# Altium schematic sheet FM.SchDoc(FM)
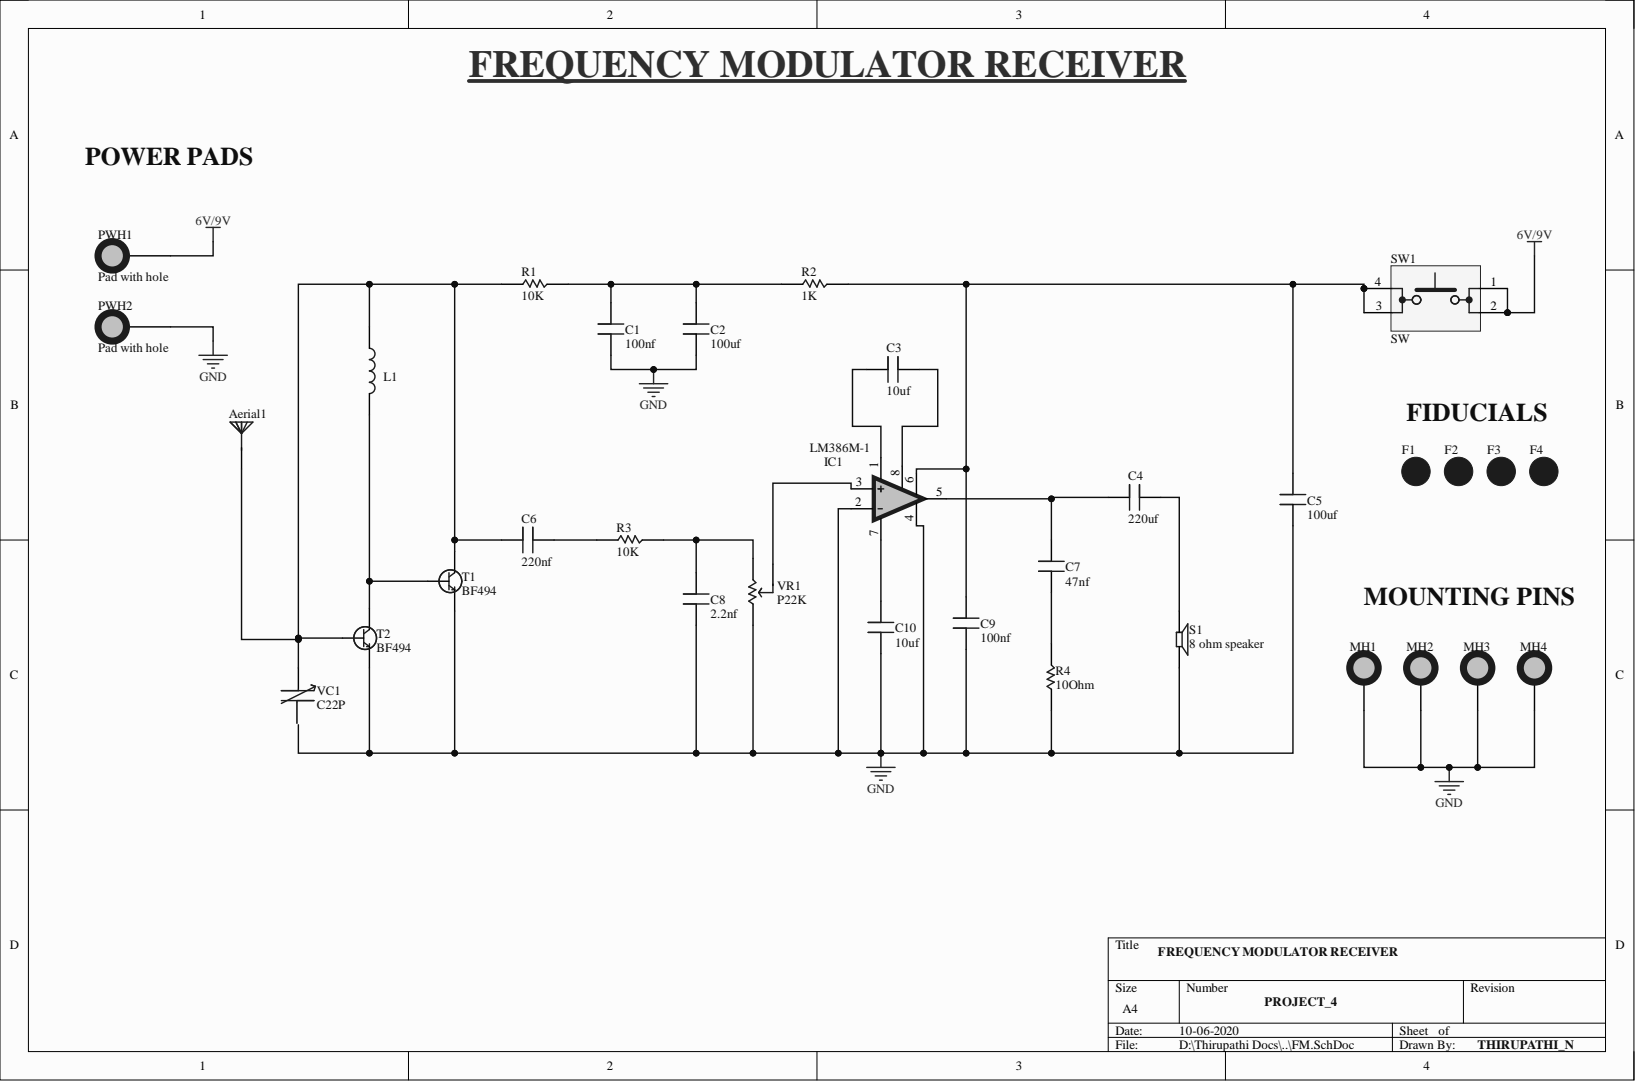
[diagram p0: FM.SchDoc]

## Parts
Aerial1  Aerial  [Aerial]
C1  100nf  [C0805]
C2  100uf  [C0805]
C3  10uf  [C0805]
C4  220uf  [C220uf]
C5  100uf  [C0805]
C6  220nf  [C0805]
C7  47nf  [C0805]
C8  2.2nf  [C0805]
C9  100nf  [C0805]
C10  10uf  [C0805]
F1  fid  [fid]  (value not drawn)
F2  fid  [fid]  (value not drawn)
F3  fid  [fid]  (value not drawn)
F4  fid  [fid]  (value not drawn)
IC1  LM386M-1  [LM386]
L1  L  [L]
MH1  mounting holes  [Mounting Holes]  (value not drawn)
MH2  mounting holes  [Mounting Holes]  (value not drawn)
MH3  mounting holes  [Mounting Holes]  (value not drawn)
MH4  mounting holes  [Mounting Holes]  (value not drawn)
PWH1  Pad with hole  [PWH]
PWH2  Pad with hole  [PWH]
R1  10K  [R0805]
R2  1K  [R0805]
R3  10K  [R0805]
R4  10Ohm  [R0805]
S1  8 ohm speaker  [8 ohm speaker]
SW1  SW  [SW]
T1  BF494  [BF494]
T2  BF494  [BF494]
VC1  C22P  [C22P]
VR1  P22K  [P22K]

## Nets
6V/9V: PWH1.1 SW1.1 SW1.2
GND: C1.1 C2.1 C5.1 C8.1 C9.1 C10.1 IC1.2 IC1.4 MH1.1 MH2.1 MH3.1 MH4.1 PWH2.1 R4.1 S1.1 T1.2 T2.2 VR1.1
NetAerial1_1: Aerial1.1 C6.1 L1.1 R1.1 T1.3 T2.1 VC1.2
NetC1_2: C1.2 C2.2 R1.2 R2.1
NetC3_1: C3.1 IC1.1
NetC3_2: C3.2 IC1.8
NetC4_1: C4.1 C7.2 IC1.5
NetC4_2: C4.2 S1.2
NetC5_2: C5.2 C9.2 IC1.6 R2.2 SW1.3 SW1.4
NetC6_2: C6.2 R3.1
NetC7_1: C7.1 R4.2
NetC8_2: C8.2 R3.2 VR1.2
NetC10_2: C10.2 IC1.7
NetIC1_3: IC1.3 VR1.3
NetL1_2: L1.2 T1.1 T2.3

Not connected: VC1 1

## Library data of the parts
Aerial1 = Aerial: High Frequency Ceramic Solutions 4-Pin SMD T/R; Manufacturer: Johanson; Manufacturer Part Number: 2450AT43B100E; Frequency: 2.4GHz; Gain: -0.5dB; Impedance: 50R; Max Frequency: 2.5GHz; Max Power Dissipation: 2W; Number of Bands: 1; Return Loss: -9.5dB; Case/Package: SMD/SMT; Category: RF Antennas; Component Kind: Standard; ComponentLink1Description: https://datasheet.ciiva.com/24068/2450at43b100-242468-24068034.pdf?src-supplier=Mouser; ComponentLink1URL: https://datasheet.ciiva.com/24068/2450at43b100-242468-24068034.pdf?src-supplier=Mouser; ComponentLink2Description: https://datasheet.ciiva.com/2792/jti-antenna-2450at43b100-09042008-2792593.pdf?src-supplier=Digi-Key; ComponentLink2URL: https://datasheet.ciiva.com/2792/jti-antenna-2450at43b100-09042008-2792593.pdf?src-supplier=Digi-Key; ComponentLink3Description: https://datasheet.ciiva.com/7633/1860765-7633973.pdf?src-supplier=Element14; ComponentLink3URL: https://datasheet.ciiva.com/7633/1860765-7633973.pdf?src-supplier=Element14; Contact Plating: Tin; Height: 0.079inch; Length: 7mm; Library Name: FM.SchLib; Mount: Surface Mount; Number of Pins: 4; Package Quantity: 1; Packaging: Tape and Reel; Power Rating: 2W; Pricing 1: 1=0.71000, 10=0.62400, 25=0.58600, 100=0.47820, 250=0.44416, 500=0.37800 (USD); Radiation Hardening: No; REACH SVHC: Unknown; RoHS: Yes; Stock 1: 20930; Supplier 1: Digi-Key; Supplier Part Number 1: 712-1010-1-ND; Termination: Solder; Width: 2mm
C1 C9 = 100nf: Cap Cer 0.1UF 100V X7R 0805; Manufacturer: KEMET; Manufacturer Part Number: C0805X104J1RAC7800; Component Kind: Standard; ComponentLink1Description: http://datasheet.octopart.com/C0805X104J1RAC7800-KEMET-datasheet-84169754.pdf; ComponentLink1URL: http://datasheet.octopart.com/C0805X104J1RAC7800-KEMET-datasheet-84169754.pdf; Library Name: FM.SchLib; Pricing 1: 1=0.54000, 10=0.38200, 50=0.28840, 100=0.25130, 500=0.18612, 1000=0.15820 (USD); Stock 1: 2400; Supplier 1: Digi-Key; Supplier Part Number 1: 399-C0805X104J1RAC7800CT-ND
C2 C5 = 100uf: Chip Capacitor; 0805; X5R; 6.3V; 100uF; ±20%; Manufacturer: Venkel; Manufacturer Part Number: C0805X5R6R3-107MNE; Component Kind: Standard; Library Name: FM.SchLib; RoHS: No; Supplier 1: Digi-Key; Supplier Part Number 1: 2679-C0805X5R6R3-107MNETR-ND
C3 C10 = 10uf: Multilayer Ceramic Capacitors MLCC - SMD/SMT 6.3volts 10uF X5R 10%; Manufacturer: KEMET; Manufacturer Part Number: C0805L106K9PACTU; Voltage Rating: 6.3V; Capacitance: 10uF; Case Code (Imperial): 0805; Case Code (Metric): 2012; Case/Package: 0805; Category: Ceramic Capacitors; Component Kind: Standard; ComponentLink1Description: https://datasheet.ciiva.com/13379/kem-c1006-x5r-smd-504728-13379146.pdf?src-supplier=Mouser; ComponentLink1URL: https://datasheet.ciiva.com/13379/kem-c1006-x5r-smd-504728-13379146.pdf?src-supplier=Mouser; ComponentLink2Description: https://datasheet.ciiva.com/148/mlcclowprofile-148553.pdf?src-supplier=Digi-Key; ComponentLink2URL: https://datasheet.ciiva.com/148/mlcclowprofile-148553.pdf?src-supplier=Digi-Key; ComponentLink3Description: https://datasheet.ciiva.com/148/mlcclowprofile-148551.pdf?src-supplier=Digi-Key; ComponentLink3URL: https://datasheet.ciiva.com/148/mlcclowprofile-148551.pdf?src-supplier=Digi-Key; ComponentLink4Description: https://datasheet.ciiva.com/148/mlcclowprofile-148552.pdf?src-supplier=Digi-Key; ComponentLink4URL: https://datasheet.ciiva.com/148/mlcclowprofile-148552.pdf?src-supplier=Digi-Key; Dielectric: X5R; Length: 0.079inch; Library Name: FM.SchLib; Max Operating Temperature: 85°C; Min Operating Temperature: -55°C; Mount: Surface Mount; Package Quantity: 4000; Packaging: Tape and Reel; Pricing 1: 1=0.99000, 10=0.75100, 50=0.62380, 100=0.53710, 500=0.41580, 1000=0.35805 (USD); RoHS: Yes; Stock 1: 3700; Supplier 1: Digi-Key; Supplier Part Number 1: 399-5930-1-ND; Termination: SMD/SMT; Thickness: 0.037inch; Tolerance: 10%; Voltage Rating (DC): 6.3V; Width: 0.049inch
C4 = 220uf: CAP CER 220UF 6.3V X5R 1206; Manufacturer: Murata; Manufacturer Part Number: GRM31CR60J227ME11L; Tolerance: 20%; Capacitance: 220uF; Case Code (Imperial): 1206; Case Code (Metric): 3216; Case/Package: 1206; Category: Ceramic Capacitors; Component Kind: Standard; ComponentLink1Description: http://www.farnell.com/datasheets/2867879.pdf?src-supplier=Farnell; ComponentLink1URL: http://www.farnell.com/datasheets/2867879.pdf?src-supplier=Farnell; ComponentLink2Description: http://www.farnell.com/datasheets/2867879.pdf?src-supplier=Element14; ComponentLink2URL: http://www.farnell.com/datasheets/2867879.pdf?src-supplier=Element14; ComponentLink3Description: http://www.farnell.com/datasheets/1957826.pdf?src-supplier=Newark; ComponentLink3URL: http://www.farnell.com/datasheets/1957826.pdf?src-supplier=Newark; ComponentLink4Description: http://search.murata.co.jp/Ceramy/image/img/A01X/partnumbering_e_01.pdf?src-supplier=Digi-Key; ComponentLink4URL: http://search.murata.co.jp/Ceramy/image/img/A01X/partnumbering_e_01.pdf?src-supplier=Digi-Key; ComponentLink5Description: http://search.murata.co.jp/Ceramy/image/img/A01X/G101/ENG/GRM31CR60J227ME11-01.pdf?src-supplier=Digi-Key; ComponentLink5URL: http://search.murata.co.jp/Ceramy/image/img/A01X/G101/ENG/GRM31CR60J227ME11-01.pdf?src-supplier=Digi-Key; Dielectric: X5R; Length: 0.126inch; Library Name: FM.SchLib; Max Operating Temperature: 85°C; Min Operating Temperature: -55°C; Mount: Surface Mount; Packaging: Cut Tape; Pricing 1: 1=1.60000, 10=1.26100, 50=1.09320, 100=0.94610, 500=0.71480, 1000=0.63071 (USD); REACH SVHC: No SVHC; RoHS: Yes; Stock 1: 333882; Supplier 1: Digi-Key; Supplier Part Number 1: 490-13970-1-ND; Thickness: 0.075inch; Voltage Rating: 6.3V; Voltage Rating (DC): 6.3V; Width: 0.063inch
C6 = 220nf: Cap Ceramic 0.22uF 100V X7R 5% SMD 0805 125°C T/R; Manufacturer: KEMET; Manufacturer Part Number: C0805C224J1REC7800; Category: Ceramic Capacitors; Component Kind: Standard; Library Name: FM.SchLib; Pricing 1: 1=0.30000, 10=0.20400, 50=0.13600, 100=0.11560, 500=0.08158, 1000=0.07138 (USD); RoHS: No; Stock 1: 2456; Supplier 1: Digi-Key; Supplier Part Number 1: 399-C0805C224J1REC7800CT-ND
C7 = 47nf: Manufacturer: KEMET; Manufacturer Part Number: C0805C473J3GEC7800; Component Kind: Standard; Library Name: FM.SchLib; Pricing 1: 1=0.44000, 10=0.30600, 50=0.21440, 100=0.18380, 500=0.13786, 1000=0.11641 (USD); RoHS: No; Stock 1: 645; Supplier 1: Digi-Key; Supplier Part Number 1: 399-C0805C473J3GEC7800CT-ND
C8 = 2.2nf: Cap Ceramic 0.0022uF 100V X7R 10% SMD 0805 125°C Paper T/R; Manufacturer: KEMET; Manufacturer Part Number: C0805X222K1RAC7800; Capacitance: 2.2nF; Case/Package: 0805; Category: Ceramic Capacitors; Component Kind: Standard; ComponentLink1Description: http://capacitoredge.kemet.com/capedge2/DataSheet?pn=C0805X222K1RAC7800&src-supplier=Avnet; ComponentLink1URL: http://capacitoredge.kemet.com/capedge2/DataSheet?pn=C0805X222K1RAC7800&src-supplier=Avnet; ComponentLink2Description: http://capacitoredge.kemet.com/capedge2/DataSheet?pn=C0805X222K1RACTU&src-supplier=Avnet+Europe; ComponentLink2URL: http://capacitoredge.kemet.com/capedge2/DataSheet?pn=C0805X222K1RACTU&src-supplier=Avnet+Europe; Depth: 1.25mm; Dielectric: X7R; Dielectric Material: Ceramic; Height: 0.78mm; Length: 2.1mm; Library Name: FM.SchLib; Max Operating Temperature: 125°C; Min Operating Temperature: -55°C; Mount: Surface Mount; Pricing 1: 1=0.38000, 10=0.26700, 50=0.18660, 100=0.16000, 500=0.12002, 1000=0.10135 (USD); Radiation Hardening: No; RoHS: Yes; Stock 1: 4000; Supplier 1: Digi-Key; Supplier Part Number 1: 399-C0805X222K1RAC7800CT-ND; Tolerance: 10%; Voltage Rating (DC): 100V
IC1 = LM386M-1: Audio Amp Speaker 1-CH Mono 0.325W Class-AB 8-Pin SOIC N Rail; Manufacturer: TI National Semiconductor; Bandwidth: 300kHz; Input Bias Current: 250nA; Input Offset Voltage (Vos): 2.5mV; Load Impedance: 8R; Max Output Power: 325mW; Max Power Dissipation: 730mW; Max Supply Voltage: 12V; Min Supply Voltage: 4V; Nominal Supply Current: 4mA; Number of Amplifiers: 1; Power Supply Rejection Ratio (PSRR): 50dB; Quiescent Current: 8mA; Supply Type: Single; Voltage Gain: 46dB; Case/Package: D; Category: Amplifiers - Audio; Component Kind: Standard; ComponentLink1Description: https://datasheet.ciiva.com/27746/82-0264e-27746609.pdf?src-supplier=Rapid+Electronics; ComponentLink1URL: https://datasheet.ciiva.com/27746/82-0264e-27746609.pdf?src-supplier=Rapid+Electronics; ComponentLink2Description: https://datasheet.ciiva.com/14667/0900766b800333d0-14667266.pdf?src-supplier=RSComponents; ComponentLink2URL: https://datasheet.ciiva.com/14667/0900766b800333d0-14667266.pdf?src-supplier=RSComponents; ComponentLink3Description: https://datasheet.ciiva.com/19456/193623-19456045.pdf?src-supplier=Jameco; ComponentLink3URL: https://datasheet.ciiva.com/19456/193623-19456045.pdf?src-supplier=Jameco; ComponentLink4Description: https://datasheet.ciiva.com/26842/lm386m-1-26842536.pdf?src-supplier=IIIC; ComponentLink4URL: https://datasheet.ciiva.com/26842/lm386m-1-26842536.pdf?src-supplier=IIIC; ComponentLink5Description: https://datasheet.ciiva.com/26330/142336-da-01-en-ic-smd-lm-386-m-1-so8-ti-26330975.pdf?src-supplier=Conrad; ComponentLink5URL: https://datasheet.ciiva.com/26330/142336-da-01-en-ic-smd-lm-386-m-1-so8-ti-26330975.pdf?src-supplier=Conrad; ComponentLink6Description: https://datasheet.ciiva.com/1480/1640767-1480992.pdf?src-supplier=Element14; ComponentLink6URL: https://datasheet.ciiva.com/1480/1640767-1480992.pdf?src-supplier=Element14; ComponentLink7Description: https://datasheet.ciiva.com/1482/1640767-1482305.pdf?src-supplier=Element14; ComponentLink7URL: https://datasheet.ciiva.com/1482/1640767-1482305.pdf?src-supplier=Element14; ComponentLink8Description: https://datasheet.ciiva.com/2967/lm386-2967890.pdf?src-supplier=Avnet; ComponentLink8URL: https://datasheet.ciiva.com/2967/lm386-2967890.pdf?src-supplier=Avnet; Height: 1.45mm; Lead Free: Contains Lead; Length: 4.9mm; Library Name: FM.SchLib; Manufacturer Part Number: LM386M-1; Max Operating Temperature: 70°C; Min Operating Temperature: 0°C; Number of Channels: 1; Number of Pins: 8; Output Power: 325mW; Package Quantity: 95; Packaging: Rail/Tube; Power Dissipation: 730mW; Pricing 1: 1=1.39000, 10=1.24500, 25=1.18160, 100=0.90730, 250=0.80180, 500=0.75960 (USD); REACH SVHC: No SVHC; RoHS: Yes; Stock 1: 607; Supplier 1: Digi-Key; Supplier Part Number 1: LM386M-1-ND; Termination: SMD/SMT; Unity Gain Bandwidth Product: 300kHz; Weight: 0.006lb; Width: 3.9mm
L1 = L: Fixed Inductors 0402 AIR CORE 1.5nH .3nH; Manufacturer: Murata; Manufacturer Part Number: LQG15HN1N5S00D; DC Resistance (DCR): 100mR; Max DC Current: 300mA; Self Resonant Frequency: 6GHz; Case/Package: 0402; Category: Fixed Inductors; Component Kind: Standard; ComponentLink1Description: http://www.mouser.com/ds/2/281/o05e-522697.pdf?src-supplier=Mouser; ComponentLink1URL: http://www.mouser.com/ds/2/281/o05e-522697.pdf?src-supplier=Mouser; Inductance: 1.5nH; Length: 1mm; Library Name: FM.SchLib; Package Quantity: 10000; Packaging: Tape and Reel; RoHS: Yes; Supplier 1: Mouser; Supplier Part Number 1: 81-LQG15HN1N5S00D; Termination: SMD/SMT; Width: 0.5mm
R1 R3 = 10K: BOURNS         CR0805-JW-103ELF            Surface Mount Chip Resistor, Thick Film, CR Series, 10 kohm, 125 mW,  5%, 150 V; Max Power Dissipation: 125mW; Resistance: 10kR; Temperature Coefficient: 200ppm/°C; Voltage Rating: 150V; Voltage Rating (DC): 100V; Case Code (Imperial): 0805; Case Code (Metric): 2012; Case/Package: 0805; Category: Chip SMD Resistors; Component Kind: Standard; ComponentLink1Description: https://datasheet.ciiva.com/11821/0900766b80fcdb72-11821135.pdf?src-supplier=RSComponents; ComponentLink1URL: https://datasheet.ciiva.com/11821/0900766b80fcdb72-11821135.pdf?src-supplier=RSComponents; ComponentLink2Description: https://datasheet.ciiva.com/9122/chpreztr-9122374.pdf?src-supplier=Avnet; ComponentLink2URL: https://datasheet.ciiva.com/9122/chpreztr-9122374.pdf?src-supplier=Avnet; ComponentLink3Description: https://datasheet.ciiva.com/22693/1902866-22693254.pdf?src-supplier=Element14; ComponentLink3URL: https://datasheet.ciiva.com/22693/1902866-22693254.pdf?src-supplier=Element14; ComponentLink4Description: https://datasheet.ciiva.com/7986/691949-7986187.pdf?src-supplier=Element14; ComponentLink4URL: https://datasheet.ciiva.com/7986/691949-7986187.pdf?src-supplier=Element14; ComponentLink5Description: https://datasheet.ciiva.com/30065/159chpreztr-30065976.pdf?src-supplier=Onlinecomponents.com; ComponentLink5URL: https://datasheet.ciiva.com/30065/159chpreztr-30065976.pdf?src-supplier=Onlinecomponents.com; ComponentLink6Description: https://datasheet.ciiva.com/16783/70154685-16783482.pdf?src-supplier=Allied+Electronics; ComponentLink6URL: https://datasheet.ciiva.com/16783/70154685-16783482.pdf?src-supplier=Allied+Electronics; ComponentLink7Description: https://datasheet.ciiva.com/5548/chpreztr-5548491.pdf?src-supplier=Mouser; ComponentLink7URL: https://datasheet.ciiva.com/5548/chpreztr-5548491.pdf?src-supplier=Mouser; ComponentLink8Description: https://datasheet.ciiva.com/6559/chpreztr-6559900.pdf?src-supplier=Digi-Key; ComponentLink8URL: https://datasheet.ciiva.com/6559/chpreztr-6559900.pdf?src-supplier=Digi-Key; ComponentLink9Description: https://datasheet.ciiva.com/6559/chpreztr-6559901.pdf?src-supplier=Digi-Key; ComponentLink9URL: https://datasheet.ciiva.com/6559/chpreztr-6559901.pdf?src-supplier=Digi-Key; ComponentLink10Description: https://datasheet.ciiva.com/7985/1856384-7985869.pdf?src-supplier=Element14; ComponentLink10URL: https://datasheet.ciiva.com/7985/1856384-7985869.pdf?src-supplier=Element14; ComponentLink11Description: https://datasheet.ciiva.com/48692/001055517-da-01-en-smd-wid-cr0805-jw-103elf-10k-ohm-1-8w-48692118.pdf?src-supplier=Conrad; ComponentLink11URL: https://datasheet.ciiva.com/48692/001055517-da-01-en-smd-wid-cr0805-jw-103elf-10k-ohm-1-8w-48692118.pdf?src-supplier=Conrad; Contact Plating: Tin; Depth: 1.25mm; Features: Moisture Resistant; Height: 0.5mm; Length: 2mm; Library Name: FM.SchLib; Manufacturer: Bourns; Manufacturer Part Number: CR0805-JW-103ELF; Max Operating Temperature: 155°C; Military Standard: Not; Min Operating Temperature: -55°C; Mount: Surface Mount; Number of Pins: 2; Number of Terminations: 2; Package Quantity: 1; Packaging: Tape and Reel; Power Rating: 125mW; Pricing 1: 1=0.10000, 10=0.01900, 100=0.00770, 1000=0.00345, 2500=0.00299 (USD); Radiation Hardening: No; REACH SVHC: No SVHC; Resistor Type: Thick Film; RoHS: Yes; Stock 1: 237038; Supplier 1: Digi-Key; Supplier Part Number 1: CR0805-JW-103ELFCT-ND; Termination: SMD/SMT; Thickness: 508um; Tolerance: 5%; Width: 1.25mm
R2 = 1K: RES SMD 1K OHM 5% 1/8W 0805; Manufacturer: Bourns; Manufacturer Part Number: CR0805-JW-102ELF; Max Power Dissipation: 125mW; Resistance: 1kR; Temperature Coefficient: 200ppm/°C; Voltage Rating: 150V; Case Code (Imperial): 0805; Case Code (Metric): 2012; Case/Package: 0805; Category: Chip SMD Resistors; Component Kind: Standard; ComponentLink1Description: https://datasheet.ciiva.com/11821/0900766b80fcdb72-11821134.pdf?src-supplier=RSComponents; ComponentLink1URL: https://datasheet.ciiva.com/11821/0900766b80fcdb72-11821134.pdf?src-supplier=RSComponents; ComponentLink2Description: https://datasheet.ciiva.com/15225/getdatasheetpartid-78155-15225381.pdf?src-supplier=Verical; ComponentLink2URL: https://datasheet.ciiva.com/15225/getdatasheetpartid-78155-15225381.pdf?src-supplier=Verical; ComponentLink3Description: https://datasheet.ciiva.com/22693/1902866-22693253.pdf?src-supplier=Element14; ComponentLink3URL: https://datasheet.ciiva.com/22693/1902866-22693253.pdf?src-supplier=Element14; ComponentLink4Description: https://datasheet.ciiva.com/7986/1856384-7986184.pdf?src-supplier=Element14; ComponentLink4URL: https://datasheet.ciiva.com/7986/1856384-7986184.pdf?src-supplier=Element14; ComponentLink5Description: https://datasheet.ciiva.com/16934/chpreztr-16934442.pdf?src-supplier=Avnet+Europe; ComponentLink5URL: https://datasheet.ciiva.com/16934/chpreztr-16934442.pdf?src-supplier=Avnet+Europe; ComponentLink6Description: https://datasheet.ciiva.com/7985/1856384-7985867.pdf?src-supplier=Element14; ComponentLink6URL: https://datasheet.ciiva.com/7985/1856384-7985867.pdf?src-supplier=Element14; ComponentLink7Description: https://datasheet.ciiva.com/30242/159chpreztr-30242765.pdf?src-supplier=Onlinecomponents.com; ComponentLink7URL: https://datasheet.ciiva.com/30242/159chpreztr-30242765.pdf?src-supplier=Onlinecomponents.com; ComponentLink8Description: https://datasheet.ciiva.com/6547/chpreztr-6547359.pdf?src-supplier=Digi-Key; ComponentLink8URL: https://datasheet.ciiva.com/6547/chpreztr-6547359.pdf?src-supplier=Digi-Key; Contact Plating: Tin; Depth: 1.25mm; Features: Moisture Resistant; Height: 0.5mm; Lead Free: Lead Free; Length: 2mm; Library Name: FM.SchLib; Max Operating Temperature: 155°C; Military Standard: Not; Min Operating Temperature: -55°C; Mount: Surface Mount; Number of Pins: 2; Number of Terminations: 2; Package Quantity: 1; Packaging: Tape and Reel; Power Rating: 125mW; Pricing 1: 1=0.10000, 10=0.01900, 100=0.00770, 1000=0.00345, 2500=0.00299 (USD); Radiation Hardening: No; REACH SVHC: No SVHC; Resistor Type: Thick Film; RoHS: Yes; Stock 1: 114420; Supplier 1: Digi-Key; Supplier Part Number 1: CR0805-JW-102ELFCT-ND; Termination: SMD/SMT; Thickness: 508um; Tolerance: 5%; Voltage Rating (DC): 150V; Width: 1.25mm
R4 = 10Ohm: RES, THICK FILM, 10R, 5%, 0.125W, 0805; Product Range:CR Series; Resistance:10ohm; Power Rating:125mW; Resistance Tolerance:± 5%; Voltage Rating:150V; Resistor Case Style:0805 [2012 Metric]; Packaging:Cut Tape; Resistor Element Material:Thick Film; Temperature Coefficient:± 200ppm/°C; MSL:-; SVHC:No SVHC (15-Jun-2015); Manufacturer: Bourns; Manufacturer Part Number: CR0805-JW-100ELF; Max Power Dissipation: 125mW; Voltage Rating: 150V; Case Code (Imperial): 0805; Case Code (Metric): 2012; Case/Package: 0805; Category: Chip SMD Resistors; Component Kind: Standard; ComponentLink1Description: https://datasheet.ciiva.com/11808/0900766b80fcdb72-11808714.pdf?src-supplier=RSComponents; ComponentLink1URL: https://datasheet.ciiva.com/11808/0900766b80fcdb72-11808714.pdf?src-supplier=RSComponents; ComponentLink2Description: https://datasheet.ciiva.com/16934/chpreztr-16934424.pdf?src-supplier=Avnet+Europe; ComponentLink2URL: https://datasheet.ciiva.com/16934/chpreztr-16934424.pdf?src-supplier=Avnet+Europe; Contact Plating: Tin; Depth: 1.25mm; Features: Moisture Resistant; Height: 0.5mm; Length: 2mm; Library Name: FM.SchLib; Max Operating Temperature: 155°C; Military Standard: Not; Min Operating Temperature: -55°C; Mount: Surface Mount; Number of Terminations: 2; Package Quantity: 5000; Packaging: Tape and Reel; Power Rating: 125mW; Pricing 1: 1=0.10000, 10=0.01900, 100=0.00770, 1000=0.00345, 2500=0.00299 (USD); Radiation Hardening: No; REACH SVHC: No SVHC; Resistance: 10R; Resistor Type: Thick Film; RoHS: Yes; Stock 1: 29437; Supplier 1: Digi-Key; Supplier Part Number 1: CR0805-JW-100ELFCT-ND; Temperature Coefficient: 200ppm/°C; Termination: SMD/SMT; Thickness: 508um; Tolerance: 5%; Voltage Rating (DC): 150V; Width: 1.25mm
S1 = 8 ohm speaker: SPEAKER 8OHM 200MW TOP PORT 87DB; Manufacturer: CUI; Impedance: 8R; Max Power Dissipation: 500mW; Shape: Round; Category: Uncategorized; Component Kind: Standard; ComponentLink1Description: http://www.mouser.com/ds/2/670/cvs-1708-515782.pdf?src-supplier=Mouser; ComponentLink1URL: http://www.mouser.com/ds/2/670/cvs-1708-515782.pdf?src-supplier=Mouser; ComponentLink2Description: https://www.cui.com/product/resource/digikeypdf/cvs-1708.pdf?src-supplier=Digi-Key; ComponentLink2URL: https://www.cui.com/product/resource/digikeypdf/cvs-1708.pdf?src-supplier=Digi-Key; Height: 8mm; Lead Free: Lead Free; Library Name: FM.SchLib; Manufacturer Part Number: CVS-1708; Power Rating: 200mW; Pricing 1: 1=3.00000, 10=2.48900, 25=2.29760, 50=2.15880, 100=2.02510, 500=1.73500, 1000=1.71600 (USD); Resistance: 8R; RoHS: Yes; Stock 1: 5605; Supplier 1: Digi-Key; Supplier Part Number 1: 102-2499-ND; Termination: PC Pins
SW1 = SW: Switch Push Button OFF (ON) SPST Round Button 0.05A 24VDC Momentary Contact PC Pins Thru-Hole; Manufacturer: TE Connectivity; Manufacturer Part Number: 3-1825910-1; Actuator Length: 13.4mm; Actuator Material: Nylon; Actuator Type: Standard; Contact Current Rating: 50mA; Contact Resistance: 100mR; Electrical Life: 100000Cycles; Illumination Type: Non-Illuminated,Illuminated; Max Voltage Rating (DC): 24V; Operating Force: 160gf; Switch Function: Off-Mom; Switch Travel: 0.25mm; Category: Pushbutton Switches; Component Kind: Standard; ComponentLink1Description: https://datasheet.ciiva.com/30188/3-1825910-1rqpn3-1825910-1-30188833.pdf?src-supplier=Onlinecomponents.com; ComponentLink1URL: https://datasheet.ciiva.com/30188/3-1825910-1rqpn3-1825910-1-30188833.pdf?src-supplier=Onlinecomponents.com; ComponentLink2Description: https://datasheet.ciiva.com/15184/getdatasheetpartid-154942-15184426.pdf?src-supplier=Verical; ComponentLink2URL: https://datasheet.ciiva.com/15184/getdatasheetpartid-154942-15184426.pdf?src-supplier=Verical; ComponentLink3Description: https://datasheet.ciiva.com/25789/921681-25789223.pdf?src-supplier=Farnell; ComponentLink3URL: https://datasheet.ciiva.com/25789/921681-25789223.pdf?src-supplier=Farnell; ComponentLink4Description: https://datasheet.ciiva.com/45260/eng-cd-1825910-c9-45260023.pdf?src-supplier=Digi-Key; ComponentLink4URL: https://datasheet.ciiva.com/45260/eng-cd-1825910-c9-45260023.pdf?src-supplier=Digi-Key; ComponentLink5Description: https://datasheet.ciiva.com/1532/1061634-1532424.pdf?src-supplier=Element14; ComponentLink5URL: https://datasheet.ciiva.com/1532/1061634-1532424.pdf?src-supplier=Element14; Contact Material: Copper Alloy; Contact Plating: Silver; Depth: 7.8mm; Length: 5.99mm; Library Name: FM.SchLib; Max Current Rating: 50mA; Max Operating Temperature: 85°C; Mechanical Life: 100000Cycles; Min Operating Temperature: -35°C; Mount: Through Hole; Orientation: Straight; Package Quantity: 750; Packaging: Loose Piece; Pricing 1: 1=0.18000, 10=0.17600, 25=0.16680, 100=0.15180, 250=0.14268, 500=0.13054, 1000=0.10930, 2500=0.10626, 5000=0.10019 (USD); REACH SVHC: Unknown; RoHS: Yes; Stock 1: 15414; Supplier 1: Digi-Key; Supplier Part Number 1: 450-1643-ND; Termination: PC Pins; Throw Configuration: SPST; Type: Switch; Voltage Rating (DC): 24V
T1 T2 = BF494: STMICROELECTRONICS - 2N2222A - TRANSISTOR, NPN, 40V, 0.6A, TO18; Collector Base Voltage (VCBO): 75V; Collector Emitter Saturation Voltage: 1V; Current Rating: 600mA; Element Configuration: Single; Emitter Base Voltage (VEBO): 6V; Frequency: 300MHz; hFE Min: 40; Max Power Dissipation: 500mW; Number of Elements: 1; Type: Switch; Case/Package: TO-18; Category: BJTs; Collector Emitter Breakdown Voltage: 40V; Collector Emitter Voltage (VCEO): 40V; Component Kind: Standard; ComponentLink1Description: https://datasheet.ciiva.com/25917/cd00003223-25917094.pdf?src-supplier=Avnet+Europe; ComponentLink1URL: https://datasheet.ciiva.com/25917/cd00003223-25917094.pdf?src-supplier=Avnet+Europe; ComponentLink2Description: https://datasheet.ciiva.com/1194/1449532-1194083.pdf?src-supplier=Element14; ComponentLink2URL: https://datasheet.ciiva.com/1194/1449532-1194083.pdf?src-supplier=Element14; ComponentLink3Description: https://datasheet.ciiva.com/977/cd00003223-977271.pdf?src-supplier=Digi-Key; ComponentLink3URL: https://datasheet.ciiva.com/977/cd00003223-977271.pdf?src-supplier=Digi-Key; ComponentLink4Description: https://datasheet.ciiva.com/16427/cd00003223-16427384.pdf?src-supplier=Future+Electronics; ComponentLink4URL: https://datasheet.ciiva.com/16427/cd00003223-16427384.pdf?src-supplier=Future+Electronics; ComponentLink5Description: https://datasheet.ciiva.com/15192/getdatasheetpartid-292165-15192875.pdf?src-supplier=Verical; ComponentLink5URL: https://datasheet.ciiva.com/15192/getdatasheetpartid-292165-15192875.pdf?src-supplier=Verical; ComponentLink6Description: https://datasheet.ciiva.com/14166/2n2222a-eng-tds-14166093.pdf?src-supplier=Distrelec; ComponentLink6URL: https://datasheet.ciiva.com/14166/2n2222a-eng-tds-14166093.pdf?src-supplier=Distrelec; ComponentLink7Description: https://datasheet.ciiva.com/29323/163147-da-01-en-transistor-2-n-2222-a-to18-stm-29323030.pdf?src-supplier=Conrad; ComponentLink7URL: https://datasheet.ciiva.com/29323/163147-da-01-en-transistor-2-n-2222-a-to18-stm-29323030.pdf?src-supplier=Conrad; Gain Bandwidth Product: 300MHz; Height: 5.3mm; Lead Free: Contains Lead; Length: 5.8mm; Library Name: FM.SchLib; Manufacturer: STMicroelectronics; Manufacturer Part Number: 2N2222A; Max Collector Current: 600mA; Max Frequency: 300MHz; Max Operating Temperature: 175°C; Min Operating Temperature: -65°C; Mount: Through Hole; Number of Pins: 3; Package Quantity: 1000; Packaging: Bulk; Polarity: NPN; Power Dissipation: 500mW; Pricing 1: 1=1.63000 (USD); Radiation Hardening: No; REACH SVHC: No SVHC; RoHS: Yes; Supplier 1: Newark; Supplier Part Number 1: 89K1151; Transition Frequency: 300MHz; Voltage Rating (DC): 75V; Width: 5.8mm
VC1 = C22P: Trimmer / Variable Capacitors 8.0 - 40pF 100volts; Manufacturer: Knowles Voltronics; Manufacturer Part Number: JR400; Capacitance: 0.00000000004F; Q Factor: 1500; Test Frequency: 1MHz; Voltage Rating: 100V; Category: Trimmer / Variable Capacitors; Component Kind: Standard; ComponentLink1Description: http://www.knowlescapacitors.com/getmedia/76b68d57-f62f-4666-a976-d23a42593c78/9341_Voltronics-2014_Final-Proof.aspx?src-supplier=Digi-Key; ComponentLink1URL: http://www.knowlescapacitors.com/getmedia/76b68d57-f62f-4666-a976-d23a42593c78/9341_Voltronics-2014_Final-Proof.aspx?src-supplier=Digi-Key; ComponentLink2Description: http://www.dovercmp.com/voltronics/en/headernavigation/product-selector/productdetails?Product_ID=674&src-supplier=Mouser; ComponentLink2URL: http://www.dovercmp.com/voltronics/en/headernavigation/product-selector/productdetails?Product_ID=674&src-supplier=Mouser; Height: 0.045inch; Length: 0.138inch; Library Name: FM.SchLib; Max Operating Temperature: 85°C; Min Operating Temperature: -40°C; Package Quantity: 1000; Packaging: Tape and Reel; Pricing 1: 1=2.68000, 10=2.59700, 50=2.30260, 100=2.08850, 500=1.55296 (USD); Resistor Type: Ceramic; RoHS: Yes; Stock 1: 14564; Supplier 1: Digi-Key; Supplier Part Number 1: 1674-1021-1-ND; Termination: SMD/SMT; Voltage Rating (DC): 100V; Width: 0.122inch
VR1 = P22K: TRIMMER 22K OHM 0.5W PC PIN TOP; Manufacturer: Bourns; Manufacturer Part Number: 3296W-1-223LF; Max Power Dissipation: 500mW; Number of Turns: 25; Resistance: 22kR; Temperature Coefficient: 100ppm/°C; Tolerance: 10%; Category: Variable Resistors and Potentiometers; Component Kind: Standard; ComponentLink1Description: https://datasheet.ciiva.com/32423/ew3296-dat-e-32423950.pdf?src-supplier=Distrelec; ComponentLink1URL: https://datasheet.ciiva.com/32423/ew3296-dat-e-32423950.pdf?src-supplier=Distrelec; ComponentLink2Description: https://datasheet.ciiva.com/8088/3296-8088821.pdf?src-supplier=Avnet; ComponentLink2URL: https://datasheet.ciiva.com/8088/3296-8088821.pdf?src-supplier=Avnet; ComponentLink3Description: https://datasheet.ciiva.com/2161/3296-2161798.pdf?src-supplier=Digi-Key; ComponentLink3URL: https://datasheet.ciiva.com/2161/3296-2161798.pdf?src-supplier=Digi-Key; Depth: 4.83mm; Height: 0.395inch; Length: 9.53mm; Library Name: FM.SchLib; Max Operating Temperature: 125°C; Military Standard: Not; Min Operating Temperature: -55°C; Mount: Through Hole,PCB; Package Quantity: 50; Packaging: Rail/Tube; Power Rating: 500mW; Pricing 1: 1=2.41000, 10=2.11700, 25=1.89800, 50=1.82500, 100=1.75200, 250=1.67900, 500=1.38700, 1000=1.31400, 5000=1.09500 (USD); Radiation Hardening: No; REACH SVHC: No SVHC; Resistor Type: Cermet; RoHS: Yes; Stock 1: 1681; Supplier 1: Digi-Key; Supplier Part Number 1: 3296W-1-223LF-ND; Taper: Linear; Termination: Pin; Weight: 0.03oz; Width: 9.53mm
F1-F4 = fid: Component Kind: Standard; Library Name: FM.SchLib
MH1-MH4 = mounting holes: Component Kind: Standard; Library Name: FM.SchLib
PWH1 PWH2 = Pad with hole: Component Kind: Standard; Library Name: FM.SchLib
